# Altium schematic sheet Main sheet.SchDoc
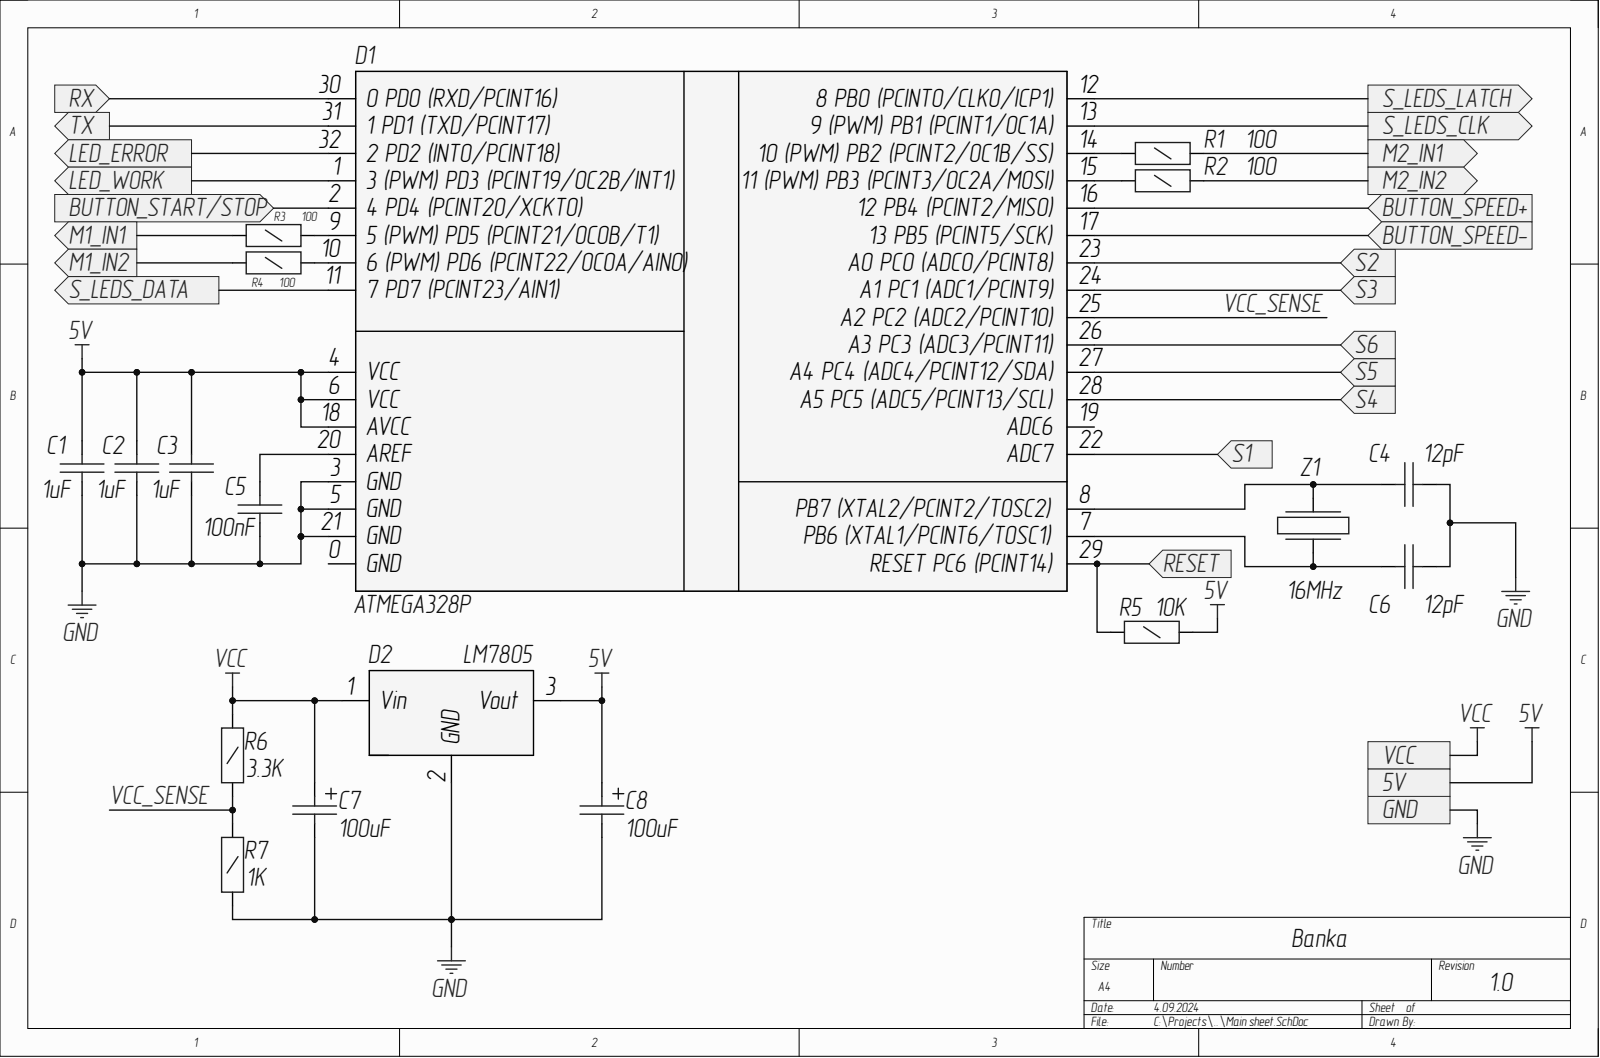
[diagram p0: Main sheet.SchDoc]

## Parts
C1  1uF  [NPC0805]
C2  1uF  [NPC0805]
C3  1uF  [NPC0805]
C4  12pF  [NPC0805]
C5  100nF  [NPC0805]
C6  12pF  [NPC0805]
C7  100uF  [TANTAL CAPACITOR C]
C8  100uF  [TANTAL CAPACITOR C]
D1  ATMEGA328P  [TQFP32]
D2  LM7805  [DPAK]
R1  100  [R0603]
R2  100  [R0603]
R3  100  [R0603]
R4  100  [R0603]
R5  10K  [R0603]
R6  3.3K  [R0603]
R7  1K  [R0603]
Z1  16MHz  [QUARTZ]

## Ports
5V, BUTTON_SPEED+, BUTTON_SPEED-, BUTTON_START/STOP, GND, LED_ERROR, LED_WORK, M1_IN1, M1_IN2, M2_IN1, M2_IN2, RESET, RX, S1, S2, S3, S4, S5, S6, S_LEDS_CLK, S_LEDS_DATA, S_LEDS_LATCH, TX, VCC

## Nets
5V: C1.2 C2.2 C3.2 C8.1 D1.4(VCC) D1.6(VCC) D1.18(AVCC) D2.3 R5.1 ; port 5V
BUTTON_SPEED+: D1.16(12_PB4_(PCINT2/MISO)) ; port BUTTON_SPEED+
BUTTON_SPEED-: D1.17(13_PB5_(PCINT5/SCK)) ; port BUTTON_SPEED-
BUTTON_START/STOP: D1.2(4_PD4_(PCINT20/XCKT0)) ; port BUTTON_START/STOP
GND: C1.1 C2.1 C3.1 C4.2 C5.1 C6.2 C7.2 C8.2 D1.3(GND) D1.5(GND) D1.21(GND) D2.2 R7.1 ; port GND
LED_ERROR: D1.32(2_PD2_(INT0/PCINT18)) ; port LED_ERROR
LED_WORK: D1.1(3_(PWM)_PD3_(PCINT19/OC2B/INT1)) ; port LED_WORK
M1_IN1: R3.1 ; port M1_IN1
M1_IN2: R4.1 ; port M1_IN2
M2_IN1: R1.2 ; port M2_IN1
M2_IN2: R2.2 ; port M2_IN2
RESET: D1.29(RESET_PC6_(PCINT14)) R5.2 ; port RESET
RX: D1.30(0_PD0_(RXD/PCINT16)) ; port RX
S1: D1.22(ADC7) ; port S1
S2: D1.23(A0_PC0_(ADC0/PCINT8)) ; port S2
S3: D1.24(A1_PC1_(ADC1/PCINT9)) ; port S3
S4: D1.28(A5_PC5_(ADC5/PCINT13/SCL)) ; port S4
S5: D1.27(A4_PC4_(ADC4/PCINT12/SDA)) ; port S5
S6: D1.26(A3_PC3_(ADC3/PCINT11)) ; port S6
S_LEDS_CLK: D1.13(9_(PWM)_PB1_(PCINT1/OC1A)) ; port S_LEDS_CLK
S_LEDS_DATA: D1.11(7_PD7_(PCINT23/AIN1)) ; port S_LEDS_DATA
S_LEDS_LATCH: D1.12(8_PB0_(PCINT0/CLKO/ICP1)) ; port S_LEDS_LATCH
TX: D1.31(1_PD1_(TXD/PCINT17)) ; port TX
VCC: C7.1 D2.1 R6.2 ; port VCC
VCC_SENSE: D1.25(A2_PC2_(ADC2/PCINT10)) R6.1 R7.2
NetC4_1: C4.1 D1.8(PB7_(XTAL2/PCINT2/TOSC2)) Z1.1
NetC5_2: C5.2 D1.20(AREF)
NetC6_1: C6.1 D1.7(PB6_(XTAL1/PCINT6/TOSC1)) Z1.2
NetD1_9: D1.9(5_(PWM)_PD5_(PCINT21/OC0B/T1)) R3.2
NetD1_10: D1.10(6_(PWM)_PD6_(PCINT22/OC0A/AIN0)) R4.2
NetD1_14: D1.14(10_(PWM)_PB2_(PCINT2/OC1B/SS)) R1.1
NetD1_15: D1.15(11_(PWM)_PB3_(PCINT3/OC2A/MOSI)) R2.1

Not connected: D1 0(GND) 19(ADC6)

## Library data of the parts
C1-C6 = Capacitor ceramic: Конденсатор кер.; Component Kind: Standard; Library Name: LibSCH.SchLib
  C1-C3: Value: 1uF
  C4 C6: Value: 12pF
  C5: Value: 100nF
C7 C8 = Capacitor tantal: Конденсатор пол.; Component Kind: Standard; Library Name: LibSCH.SchLib; Value: 100uF
D1 = ATMEGA328P: Микроконтроллер; Component Kind: Standard; Library Name: LibSCH.SchLib
D2 = LM7805: DC-DC преобразователь; Component Kind: Standard; Library Name: LibSCH.SchLib
R1-R7 = Резистор  0,25Вт: Резистор 0,25Вт; Power rating: 0,25Вт; Component Kind: Standard; Library Name: LibSCH.SchLib
  R1-R4: Value: 100
  R5: Value: 10K
  R6: Value: 3.3K
  R7: Value: 1K
Z1 = KX-7T: Кварцевый резонатор; Manufacturer: Geyer Electronics; Max. aging frequency at first year: +-2ppm; Temperature tolerance: 100ppm; Tolerance: 30ppm; Component Kind: Standard; Library Name: LibSCH.SchLib; Name: KX-7T; Package: SMD3,2x2,5x0,8; Temperature range: -40...85C; Value: 16MHz
